# Altium schematic sheet ESP32DRO.SchDoc
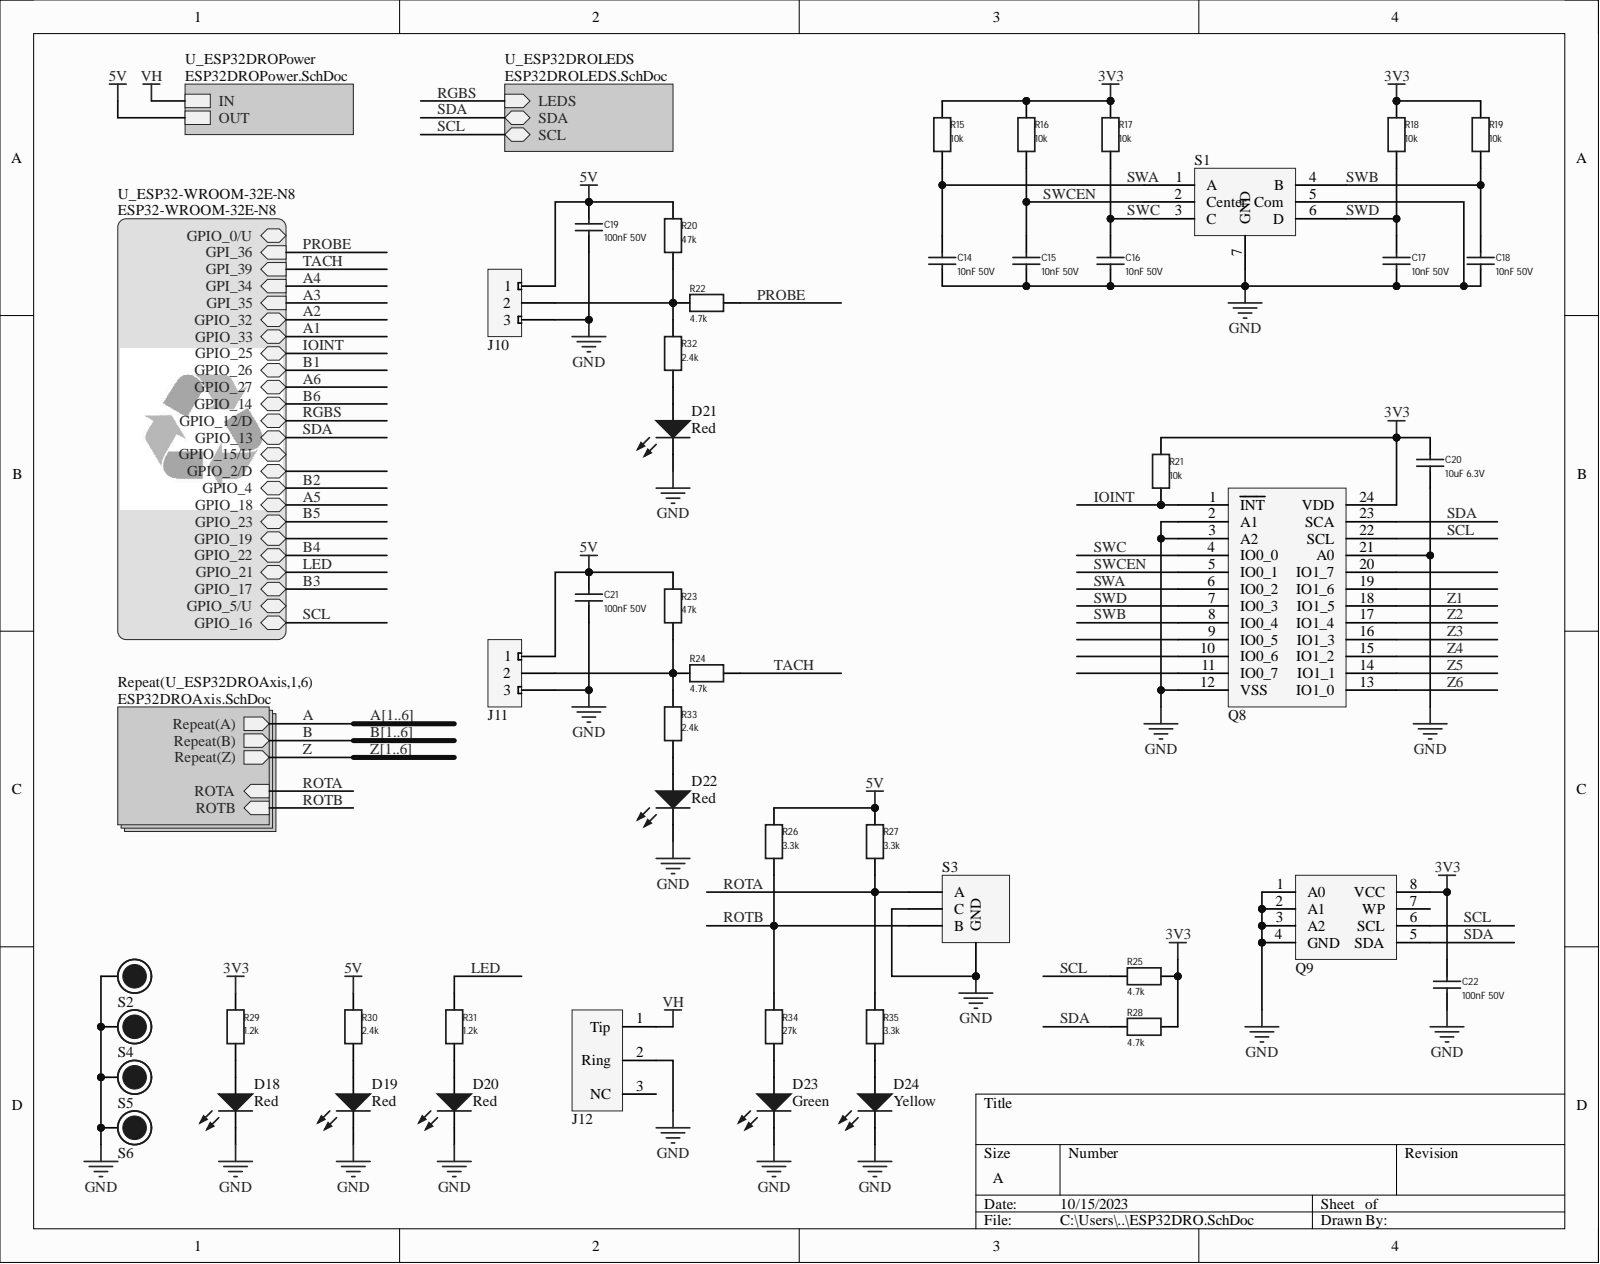
[diagram p0: ESP32DRO.SchDoc]

## Parts
C1  10nF 50V  [KiProject:CAPC1005X06N_0402]  (part 4)
C15  10nF 50V  [KiProject:CAPC1005X06N_0402]
C16  10nF 50V  [KiProject:CAPC1005X06N_0402]
C17  10nF 50V  [KiProject:CAPC1005X06N_0402]
C18  10nF 50V  [KiProject:CAPC1005X06N_0402]
C19  100nF 50V  [KiProject:CAPC1005X06N_0402]
C20  10uF 6.3V  [KiProject:CAPC1005X06N_0402]
C21  100nF 50V  [KiProject:CAPC1005X06N_0402]
C22  100nF 50V  [KiProject:CAPC1005X06N_0402]
D1  Red  [DIOC1005X04N]  (part 8)
D19  Red  [DIOC1005X04N]
D20  Red  [DIOC1005X04N]
D21  Red  [DIOC1005X04N]
D22  Red  [DIOC1005X04N]
D23  Green  [DIOC1005X04N]
D24  Yellow  [DIOC1005X04N]
J1  Header JST XH 3Pos  [Header JST XH 3Pos Straight]  (value not drawn)  (part 0)
J11  Header JST XH 3Pos  [Header JST XH 3Pos Straight]  (value not drawn)
J12  PJ-037AH  [DC POWER RECEPTACLE 5A]  (value not drawn)
Q8  GPIO_Expander_I2C_PCA9535  [TSOP65P640X120-24N]  (value not drawn)
Q9  2K EEPROM  [SOIC127P600X175-8N]  (value not drawn)
R1  10k  [KiProject:RESC1005X04N_0402]  (part 5)
R15  1.2k  [KiProject:RESC1005X04N_0402]  (part )
R16  10k  [KiProject:RESC1005X04N_0402]
R17  10k  [KiProject:RESC1005X04N_0402]
R18  10k  [KiProject:RESC1005X04N_0402]
R19  10k  [KiProject:RESC1005X04N_0402]
R20  47k  [KiProject:RESC1005X04N_0402]
R21  10k  [KiProject:RESC1005X04N_0402]
R22  4.7k  [KiProject:RESC1005X04N_0402]
R23  47k  [KiProject:RESC1005X04N_0402]
R24  4.7k  [KiProject:RESC1005X04N_0402]
R25  4.7k  [KiProject:RESC1005X04N_0402]
R26  3.3k  [KiProject:RESC1005X04N_0402]
R27  3.3k  [KiProject:RESC1005X04N_0402]
R28  4.7k  [KiProject:RESC1005X04N_0402]
R30  2.4k  [KiProject:RESC1005X04N_0402]
R31  1.2k  [KiProject:RESC1005X04N_0402]
R32  2.4k  [KiProject:RESC1005X04N_0402]
R33  2.4k  [KiProject:RESC1005X04N_0402]
R34  27k  [KiProject:RESC1005X04N_0402]
R35  3.3k  [KiProject:RESC1005X04N_0402]
S1  LCSC_PNP: Center  [Multi_Directional_Switch]  (hidden comment: Multi_Directional_Switch)
S2  MountScrew_M3  [MountScrew_M3]  (value not drawn)  (no BOM)
S3  Rotary_Encoder_EC12E24204A8  [Rotary_Encoder_EC12E24204A8]  (value not drawn)
S4  MountScrew_M3  [MountScrew_M3]  (value not drawn)  (no BOM)
S5  MountScrew_M3  [MountScrew_M3]  (value not drawn)  (no BOM)
S6  MountScrew_M3  [MountScrew_M3]  (value not drawn)  (no BOM)

## Nets
3V3: C20.2 C22.2 Q8.24(VDD) Q9.8(VCC) R1.2 R15.2 R16.2 R17.2 R18.2 R19.2 R21.2 R25.1 R28.1
5V: C19.2 C21.2 J1.1 J11.1 R20.2 R23.2 R26.2 R27.2 R30.2
GND: C1.1 C15.1 C16.1 C17.1 C18.1 C19.1 C20.1 C21.1 C22.1 D1.1 D19.1 D20.1 D21.1 D22.1 D23.1 D24.1 J1.3 J11.3 J12.2(Ring) Q8.2(A1) Q8.3(A2) Q8.12(VSS) Q8.21(A0) Q9.1(A0) Q9.2(A1) Q9.3(A2) Q9.4(GND) S1.5(Com) S1.7(GND) S2.1 S3.1(GND) S3.C S4.1 S5.1 S6.1
VH: J12.1(Tip)
IOINT: Q8.1(INT) R21.1
LED: R31.2
PROBE: R22.2
ROTA: R27.1 R35.2 S3.A
ROTB: R26.1 R34.2 S3.B
SCL: Q8.22(SCL) Q9.6(SCL) R25.2
SDA: Q8.23(SCA) Q9.5(SDA) R28.2
SWA: C1.2 Q8.6(IO0_2) R1.1 S1.1(A)
SWB: C18.2 Q8.8(IO0_4) R19.1 S1.4(B)
SWC: C16.2 Q8.4(IO0_0) R17.1 S1.3(C)
SWCEN: C15.2 Q8.5(IO0_1) R16.1 S1.2(Center)
SWD: C17.2 Q8.7(IO0_3) R18.1 S1.6(D)
TACH: R24.2
Z1: Q8.18(IO1_5)  [also labelled Z]
Z2: Q8.17(IO1_4)  [also labelled Z]
Z3: Q8.16(IO1_3)  [also labelled Z]
Z4: Q8.15(IO1_2)  [also labelled Z]
Z5: Q8.14(IO1_1)  [also labelled Z]
Z6: Q8.13(IO1_0)  [also labelled Z]
NetD1_2: D1.2 R15.1
NetD2_2: D19.2 R30.1
NetD3_2: D20.2 R31.1
NetD39_2: D21.2 R32.1
NetD40_2: D22.2 R33.1
NetD41_2: D23.2 R34.1
NetD42_2: D24.2 R35.1
NetJ1_2: J1.2 R20.1 R22.1 R32.2
NetJ2_2: J11.2 R23.1 R24.1 R33.2
NetJ3_3: J12.3(NC)
NetQ1_9: Q8.9(IO0_5)
NetQ1_10: Q8.10(IO0_6)
NetQ1_11: Q8.11(IO0_7)
NetQ1_19: Q8.19(IO1_6)
NetQ1_20: Q8.20(IO1_7)
NetQ2_7: Q9.7(WP)
A1:  [also labelled A]
A2:  [also labelled A]
A3:  [also labelled A]
A4:  [also labelled A]
A5:  [also labelled A]
A6:  [also labelled A]
B1:  [also labelled B]
B2:  [also labelled B]
B3:  [also labelled B]
B4:  [also labelled B]
B5:  [also labelled B]
B6:  [also labelled B]
GPIO_0/U:
GPIO_2/D:
GPIO_5/U:
GPIO_15/U:
GPIO_19:
RGBS:

## Library data of the parts
C1 C15-C18 = CAPC_10nF_50V_0402: Capacitor SMT 10nF 50V 0402 ±10%; Component Kind: Standard; LCSC_Assembly: SMT; LCSC_Library: Basic; LCSC_Part: C15195; LCSC_PNP: Center; Library Name: JLCPCB.IntLib; Supplier: https://datasheet.lcsc.com/lcsc/1810191223_Samsung-Electro-Mechanics-CL05B103KB5NNNC_C15195.pdf
C19 C21 C22 = CAPC_100nF_50V_0402: Capacitor SMT 100nF 50V 0402 ±10%; Component Kind: Standard; LCSC_Assembly: SMT; LCSC_Library: Basic; LCSC_Part: C307331; LCSC_PNP: Center; Library Name: JLCPCB.IntLib; Supplier: https://datasheet.lcsc.com/lcsc/1810191222_Samsung-Electro-Mechanics-CL05B104KB54PNC_C307331.pdf
C20 = CAPC_10uF_6.3V_0402: Capacitor SMT 10uF 6.3V 0402 ±20%; Component Kind: Standard; LCSC_Assembly: SMT; LCSC_Library: Basic; LCSC_Part: C15525; LCSC_PNP: Center; Library Name: JLCPCB.IntLib; Supplier: https://datasheet.lcsc.com/lcsc/2208231630_Samsung-Electro-Mechanics-CL05A106MQ5NUNC_C15525.pdf
D1 D19-D22 = LED Red 20mcd@5mA 1.8V 0402: Component Kind: Standard; Description: LED Red 20mcd@5mA 1.8V 0402; LCSC_Assembly: SMT; LCSC_Library: Extended; LCSC_Part: C130719; LCSC_PNP: Center; Library Name: JLCPCB.IntLib
D23 = LED Green 275mcd@5mA 2.75V 0402: LED Green 275mcd; Component Kind: Standard; LCSC_Assembly: SMT; LCSC_Library: Extended; LCSC_Part: C434446; LCSC_PNP: Center; Library Name: JLCPCB.IntLib
D24 = LED Yellow 24mcd@5mA 1.8V 0402: LED Yellow 24mcd; Component Kind: Standard; LCSC_Assembly: SMT; LCSC_Library: Extended; LCSC_Part: C130721; LCSC_PNP: Center; Library Name: JLCPCB.IntLib
J1 J11 = Header JST XH 3Pos Straight: Component Kind: Standard; Description: Header JST XH 3Pos; LCSC_Assembly: Wave; LCSC_Library: Extended; LCSC_Part: C144394; LCSC_PNP: Center; Library Name: JLCPCB.IntLib; Supplier 1: Newark; Supplier 2: Arrow Electronics; Supplier 3: Future Electronics; Supplier 4: Digi-Key; Supplier 5: LCSC; Supplier Part Number 1: 73M9431; Supplier Part Number 2: B3B-XH-A(LF)(SN); Supplier Part Number 3: 8262735; Supplier Part Number 4: 455-2248-ND; Supplier Part Number 5: C144394
J12 = PJ-037AH: CON PWR JCK 2.0 X 6.5MM HIGH CUR; Component Kind: Standard; LCSC_Assembly: Wave; LCSC_Library: Extended; LCSC_Part: C426213; LCSC_PNP: Center; Library Name: JLCPCB.IntLib
Q8 = GPIO_Expander_I2C_PCA9535: I2C 16-Bit I/O Expander; Component Kind: Standard; LCSC_Assembly: SMT; LCSC_Library: Extended; LCSC_Part: C255606; LCSC_PNP: Center; Library Name: JLCPCB.IntLib
Q9 = EEPROM_2Kbit: Component Kind: Standard; Description: 2K EEPROM; LCSC_Library: Basic; LCSC_Part: C7562; LCSC_PNP: Center; Library Name: JLCPCB.IntLib
R1 R16-R19 R21 = RESC_10k_0402: Resistor 10kΩ 0402 SMT ±1% 62.5mW; Component Kind: Standard; LCSC_Assembly: SMT; LCSC_Library: Basic; LCSC_Part: C25744; LCSC_PNP: Center; Library Name: JLCPCB.IntLib; Supplier: https://datasheet.lcsc.com/lcsc/2206010100_UNI-ROYAL-Uniroyal-Elec-0402WGF1002TCE_C25744.pdf
R15 R31 = RESC_1.2k_0402: Resistor 1.2kΩ 0402 SMT ±1% 62.5mW; Component Kind: Standard; LCSC_Assembly: SMT; LCSC_Library: Basic; LCSC_Part: C25862; LCSC_PNP: Center; Library Name: JLCPCB.IntLib; Supplier: https://datasheet.lcsc.com/lcsc/2206010045_UNI-ROYAL-Uniroyal-Elec-0402WGF1201TCE_C25862.pdf
R20 R23 = RESC_47k_0402: Resistor 47kΩ 0402 SMT ±1% 62.5mW; Component Kind: Standard; LCSC_Assembly: SMT; LCSC_Library: Basic; LCSC_Part: C25792; LCSC_PNP: Center; Library Name: JLCPCB.IntLib; Supplier: https://datasheet.lcsc.com/lcsc/2206010100_UNI-ROYAL-Uniroyal-Elec-0402WGF4702TCE_C25792.pdf
R22 R24 R25 R28 = RESC_4.7k_0402: Resistor 4.7kΩ 0402 SMT ±1% 62.5mW; Component Kind: Standard; LCSC_Assembly: SMT; LCSC_Library: Basic; LCSC_Part: C25900; LCSC_PNP: Center; Library Name: JLCPCB.IntLib; Supplier: https://datasheet.lcsc.com/lcsc/2206010045_UNI-ROYAL-Uniroyal-Elec-0402WGF4701TCE_C25900.pdf
R26 R27 R35 = RESC_3.3k_0402: Resistor 3.3kΩ 0402 SMT ±1% 62.5mW; Component Kind: Standard; LCSC_Assembly: SMT; LCSC_Library: Basic; LCSC_Part: C25890; LCSC_PNP: Center; Library Name: JLCPCB.IntLib; Supplier: https://datasheet.lcsc.com/lcsc/2206010045_UNI-ROYAL-Uniroyal-Elec-0402WGF3301TCE_C25890.pdf
R30 R32 R33 = RESC_2.4k_0402: Resistor 2.4kΩ 0402 SMT ±1% 62.5mW; Component Kind: Standard; LCSC_Assembly: SMT; LCSC_Library: Basic; LCSC_Part: C25882; LCSC_PNP: Center; Library Name: JLCPCB.IntLib; Supplier: https://datasheet.lcsc.com/lcsc/2206010045_UNI-ROYAL-Uniroyal-Elec-0402WGF2401TCE_C25882.pdf
R34 = RESC_27k_0402: Resistor 27kΩ 0402 SMT ±1% 62.5mW; Component Kind: Standard; LCSC_Assembly: SMT; LCSC_Library: Basic; LCSC_Part: C25771; LCSC_PNP: Center; Library Name: JLCPCB.IntLib; Supplier: https://datasheet.lcsc.com/lcsc/2206010100_UNI-ROYAL-Uniroyal-Elec-0402WGF2702TCE_C25771.pdf
S2 S4-S6 = MountScrew_M3: Screw Hole 6-32; Library Name: JLCPCB_Extended.SchLib
S1 = Multi_Directional_Switch: Component Kind: Standard; Description: Multi_Directional_Switch; LCSC_Assembly: SMT; LCSC_Library: Extended; LCSC_Part: C465996; Library Name: JLCPCB.IntLib
S3 = Rotary_Encoder_EC12E24204A8: Component Kind: Standard; Description: Rotary_Encoder_EC12E24204A8; LCSC_Assembly: Wave; LCSC_Library: Extended; LCSC_Part: C470598; LCSC_PNP: Center; Library Name: JLCPCB.IntLib
